# Altium schematic sheet [03] - BUTTONS-AND-SPI.SchDoc("[03] - BUTTONS-AND-SPI")
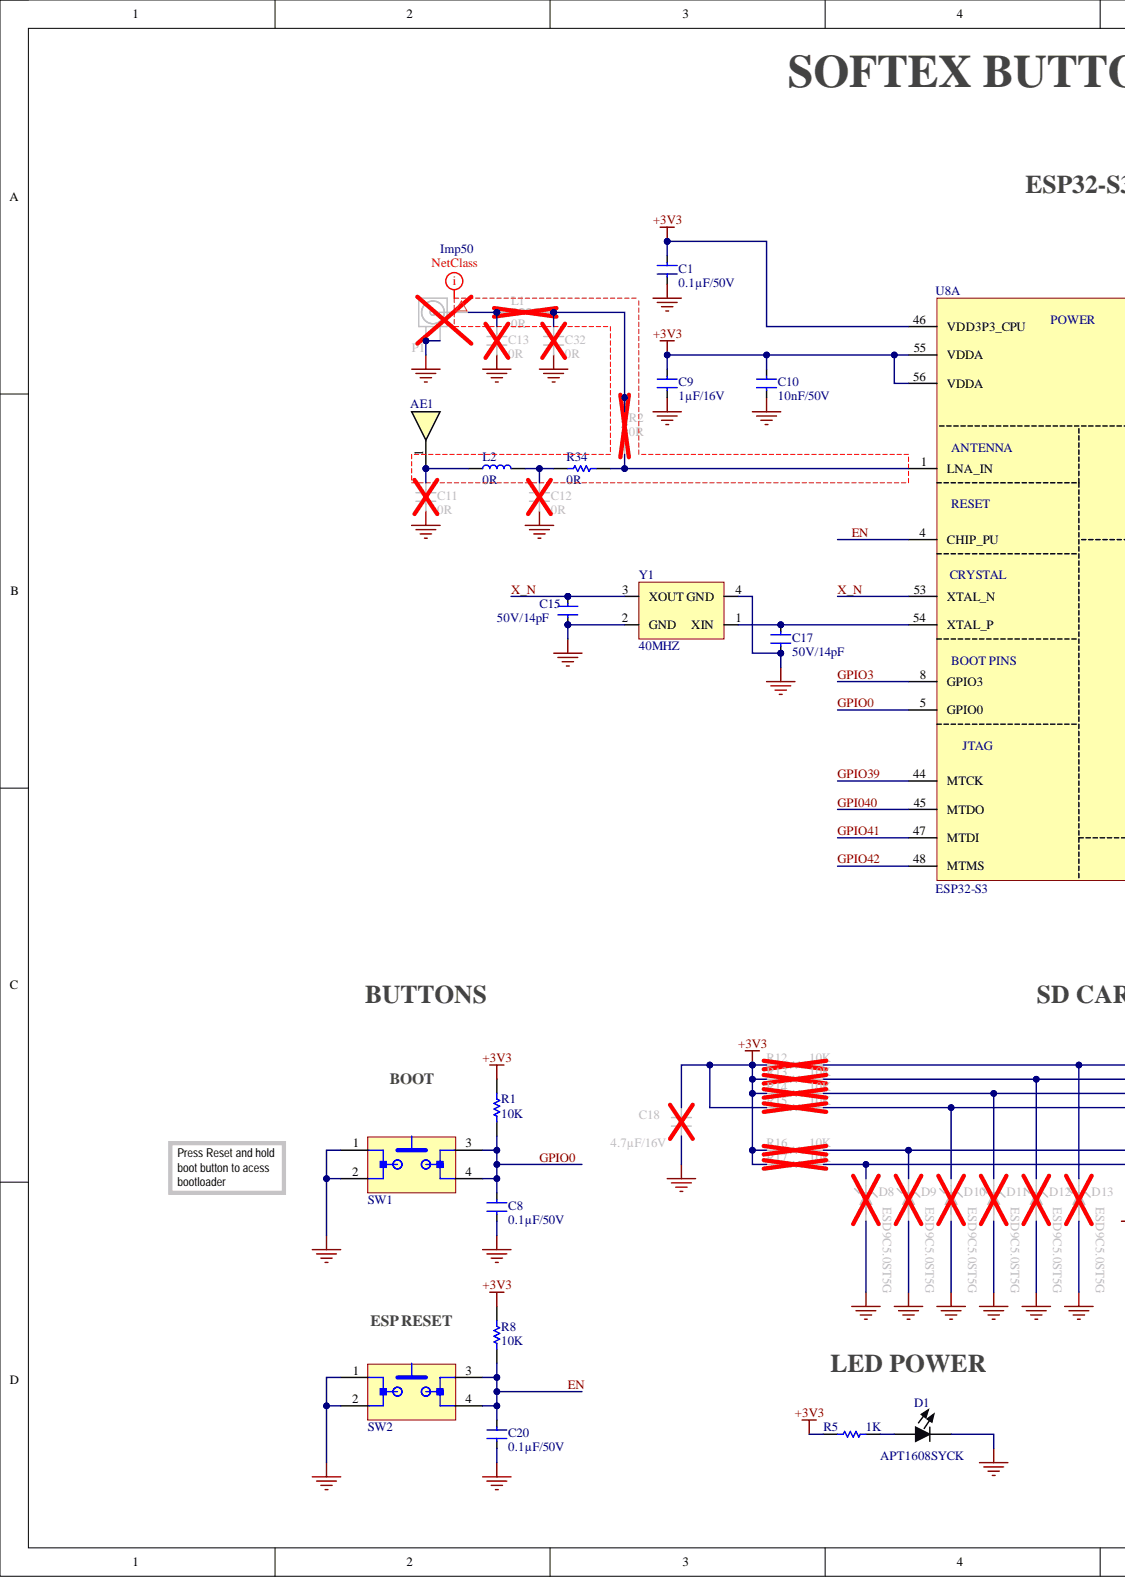
[diagram p0: [03] - BUTTONS-AND-SPI.SchDoc, left]
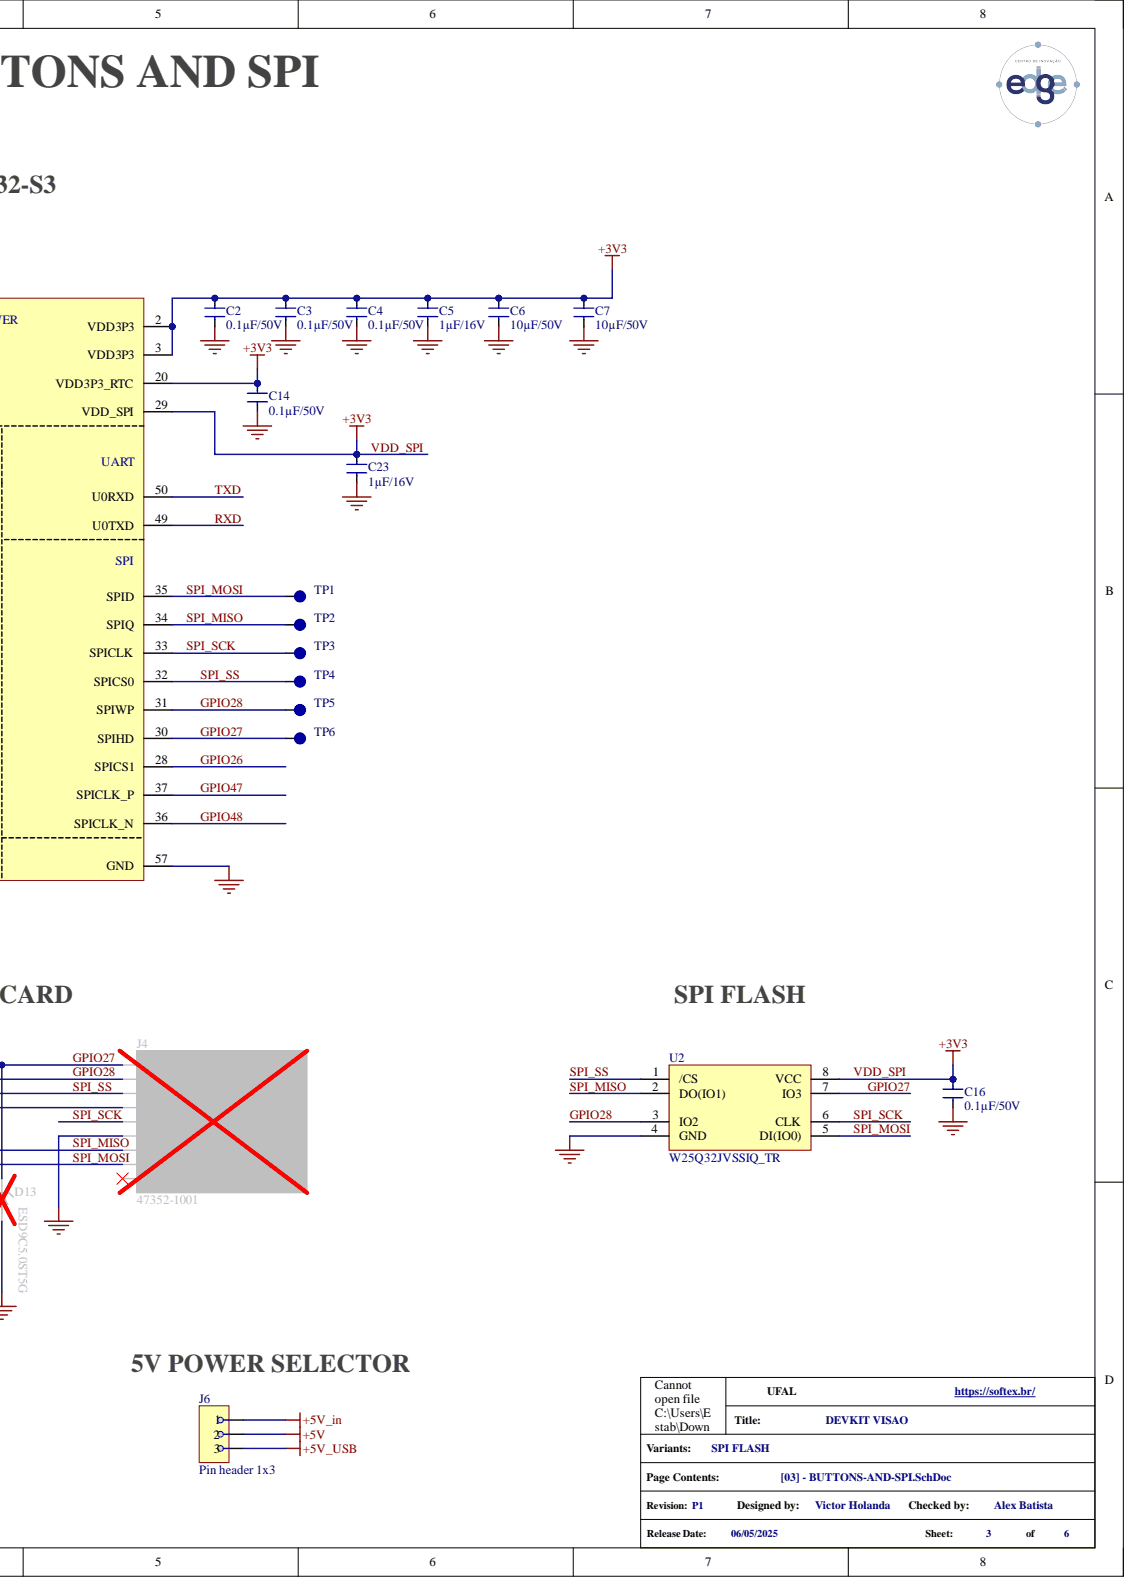
[diagram p1: [03] - BUTTONS-AND-SPI.SchDoc, right]

## Parts
AE1  2450AT45A100E  [2450AT45A100E]  (value not drawn)
C1  0.1µF/50V  [C0805]
C2  0.1µF/50V  [C0805]
C3  0.1µF/50V  [C0805]
C4  0.1µF/50V  [C0805]
C5  1µF/16V  [C0805]
C6  10µF/50V  [C0805]
C7  10µF/50V  [C0805]
C8  0.1µF/50V  [C0805]
C9  1µF/16V  [C0805]
C10  10nF/50V  [C0805]
C11  0R  [C0402]
C12  0R  [C0402]
C13  0R  [C0402]
C14  0.1µF/50V  [C0805]
C15  50V/14pF  [C0402]
C16  0.1µF/50V  [C0805]
C17  50V/14pF  [C0402]
C18  4.7µF/16V  [C0805]
C20  0.1µF/50V  [C0805]
C23  1µF/16V  [C0805]
C32  0R  [C0402]
D1  APT1608SYCK  [APT1608SYCK]
D8  ESD9C5.0ST5G  [ESD9C5.0ST5G]
D9  ESD9C5.0ST5G  [ESD9C5.0ST5G]
D10  ESD9C5.0ST5G  [ESD9C5.0ST5G]
D11  ESD9C5.0ST5G  [ESD9C5.0ST5G]
D12  ESD9C5.0ST5G  [ESD9C5.0ST5G]
D13  ESD9C5.0ST5G  [ESD9C5.0ST5G]
J4  47352-1001  [SDCARD-0473521001]
J6  Pin header 1x3  [PH1-03-UA]
L1  0R  [L0402]
L2  0R  [L0402]
P1  U.FL-R-SMT-1(10)  [U.FL-R-SMT-1(10)]  (value not drawn)
R1  10K  [R0805]
R2  0R  [R0402]
R5  1K  [R0805]
R8  10K  [R0805]
R12  10K  [R0805]
R13  10K  [R0805]
R14  10K  [R0805]
R15  10K  [R0805]
R16  10K  [R0805]
R17  10K  [R0805]
R34  0R  [R0402]
SW1  BUTTON  [BUTTON]  (value not drawn)
SW2  BUTTON  [BUTTON]  (value not drawn)
TP1  TestPoint  [Test Point]  (value not drawn)  (no BOM)
TP2  TestPoint  [Test Point]  (value not drawn)  (no BOM)
TP3  TestPoint  [Test Point]  (value not drawn)  (no BOM)
TP4  TestPoint  [Test Point]  (value not drawn)  (no BOM)
TP5  TestPoint  [Test Point]  (value not drawn)  (no BOM)
TP6  TestPoint  [Test Point]  (value not drawn)  (no BOM)
U2  W25Q32JVSSIQ_TR  [SOIC127P790X216-8N]
U8  ESP32-S3  [ESP32-S3]  (part A)
U8
Y1  40MHZ  [RH100-40.000-10-F-1010-TR]

## Nets
+5V: J6.2
+5V_in: J6.1
+5V_USB: J6.3
GND: C1.1 C2.1 C3.1 C4.1 C5.1 C6.1 C7.1 C8.1 C9.1 C10.1 C11.1 C12.1 C13.1 C14.2 C15.1 C16.1 C17.1 C18.1 C20.1 C23.1 C32.1 D1.2 D8.2 D9.2 D10.2 D11.2 D12.2 D13.2 J4.6(C6/Vss) P1.2 P1.3 SW1.1 SW1.2 SW2.1 SW2.2 U2.4(GND) U8.57 Y1.2(GND) Y1.4(GND)
+3V3: C1.2 C2.2 C3.2 C4.2 C5.2 C6.2 C7.2 C9.2 C10.2 C14.1 C16.2 C18.2 C23.2 R1.2 R5.1 R8.2 R12.1 R13.1 R14.1 R15.1 R16.1 R17.1 U2.8(VCC) U8.2 U8.3 U8.20 U8.29 U8.46 U8.55 U8.56
EN: C20.2 R8.1 SW2.3 SW2.4 U8.4
GPI040: U8.45
GPIO0: C8.2 R1.1 SW1.3 SW1.4 U8.5
GPIO3: U8.8
GPIO26: U8.28 U8.29
GPIO27: D13.1 J4.1(C1/DATA2) R12.2 TP6.1 U2.7(IO3) U8.28 U8.30
GPIO28: D12.1 J4.2(C2/CD/DATA3) R13.2 TP5.1 U2.3(IO2) U8.30 U8.31
GPIO39: U8.44
GPIO41: U8.47
GPIO42: U8.48
GPIO47: U8.37
GPIO48: U8.36
RXD: U8.49
SPI_MISO: D9.1 J4.7(C7/DATA0) R16.2 TP2.1 U2.2(DO(IO1)) U8.33 U8.34
SPI_MOSI: D8.1 J4.8(C8/DATA1) R17.2 TP1.1 U2.5(DI(IO0)) U8.34 U8.35
SPI_SCK: J4.5(C5/CLK) TP3.1 U2.6(CLK) U8.32 U8.33
SPI_SS: D11.1 J4.3(C3/CMD) R14.2 TP4.1 U2.1(/CS) U8.31 U8.32
TXD: U8.49 U8.50
X_N: C15.2 U8.53 Y1.3(XOUT)
NetAE1_1: AE1.1 C11.2 L2.1
NetC12_2: C12.2 L2.2 R34.2
NetC13_2: C13.2 L1.1 P1.1
NetC17_2: C17.2 U8.54 Y1.1(XIN)
NetC32_2: C32.2 L1.2 R2.2
NetD1_1: D1.1 R5.2
NetD10_1: D10.1 J4.4(C4/VDD) R15.2
NetR2_1: R2.1 R34.1 U8.1

Not connected: J4 9(CARD_DET)

## Library data of the parts
AE1 = 2450AT45A100E: RF ANT - 2.4GHZ CHIP SOLDER SMD; Manufacturer 1: Johanson; .PCBChecked: Alex Batista; Bandwidth: 100MHz; Frequency: 2.45GHz; Gain: 1dB; Impedance: 50R; Max Frequency: 2.5GHz; Max Power Dissipation: 3W; Number of Bands: 1; Return Loss: -9.5dB; Component Kind: Standard; ComponentLink1Description: https://datasheet.ciiva.com/1126/1296113-1126176.pdf?src-supplier=Newark; ComponentLink1URL: https://datasheet.ciiva.com/1126/1296113-1126176.pdf?src-supplier=Newark; ComponentLink2Description: https://datasheet.ciiva.com/2791/jti-antenna-2450at45a100-2006-07-2791936.pdf?src-supplier=Digi-Key; ComponentLink2URL: https://datasheet.ciiva.com/2791/jti-antenna-2450at45a100-2006-07-2791936.pdf?src-supplier=Digi-Key; ComponentLink3Description: https://datasheet.ciiva.com/6748/antenna-2450at45a100-v5-6748578.pdf?src-supplier=Newark; ComponentLink3URL: https://datasheet.ciiva.com/6748/antenna-2450at45a100-v5-6748578.pdf?src-supplier=Newark; ComponentLink4Description: https://datasheet.ciiva.com/24068/antenna-2450at45a100-v5-242465-24068002.pdf?src-supplier=Mouser; ComponentLink4URL: https://datasheet.ciiva.com/24068/antenna-2450at45a100-v5-242465-24068002.pdf?src-supplier=Mouser; ComponentLink5Description: https://datasheet.ciiva.com/2791/jti-antenna-2450at45a100-2006-07-2791937.pdf?src-supplier=Digi-Key; ComponentLink5URL: https://datasheet.ciiva.com/2791/jti-antenna-2450at45a100-2006-07-2791937.pdf?src-supplier=Digi-Key; ComponentLink6Description: https://datasheet.ciiva.com/2791/jti-antenna-2450at45a100-2006-07-2791938.pdf?src-supplier=Digi-Key; ComponentLink6URL: https://datasheet.ciiva.com/2791/jti-antenna-2450at45a100-2006-07-2791938.pdf?src-supplier=Digi-Key; ComponentLink7Description: https://datasheet.ciiva.com/1124/1318299-1124920.pdf?src-supplier=Element14; ComponentLink7URL: https://datasheet.ciiva.com/1124/1318299-1124920.pdf?src-supplier=Element14; Height: 0.047inch; Length: 9.5mm; Manufacturer Part Number 1: 2450AT45A100E; Max Operating Temperature: 85°C; Min Operating Temperature: -40°C; Mount: Surface Mount; Number of Pins: 2; Package Quantity: 1; Packaging: Tape and Reel; Power Rating: 3W; Pricing 1: 1=0.94000, 10=0.84100, 25=0.79800, 100=0.65550, 250=0.61276, 500=0.54150 (USD); Radiation Hardening: No; REACH SVHC: No SVHC; Resistor Type: Ceramic; RoHS: Yes; Stock 1: 275; Supplier 1: Digi-Key; Supplier Part Number 1: 712-1012-1-ND; Termination: Solder; Width: 2mm; .SCHChecked: Alex Batista; Library Name: Softex.SchLib
C1-C4 C8 C14 C16 C20 = CL21B104KBCNNNC: MLCC - 0.1µF 0805 50V X7R ±10%; Manufacturer 1: Samsung; .PCBChecked: Victor Holanda; Capacitance: 100nF; Material: Ceramic; Case Code (Imperial): 0805; Case Code (Metric): 2012; Case/Package: 0805; Category: Ceramic Capacitors; Component Kind: Standard; ComponentLink3Description: https://datasheet.ciiva.com/25710/cl21b104kbcnnnc-25710182.pdf?src-supplier=Digi-Key; ComponentLink3URL: https://datasheet.ciiva.com/25710/cl21b104kbcnnnc-25710182.pdf?src-supplier=Digi-Key; Construction: Flat; Contact Plating: Tin; Depth: 1.25mm; Dielectric: X7R; Height: 0.85mm; Lead Free: Lead Free; Length: 2mm; Manufacturer Part Number 1: CL21B104KBCNNNC; Max Operating Temperature: 125°C; Min Operating Temperature: -55°C; Mount: Surface Mount; Packaging: Tape and Reel; Pricing 1: 1=0,10000, 10=0,04800, 50=0,02620, 100=0,02140, 500=0,01528, 1000=0,01201 (USD); Radiation Hardening: No; RoHS: Yes; RoHS Compliant: Yes; Series: CL; Stock 1: 3198898; Supplier 1: Digi-Key; Supplier 2: Mouser; Supplier Part Number 1: 1276-1003-1-ND; Supplier Part Number 2: 187-CL21B104KBCNNNC; Thickness: 0.037inch; Tolerance: 10%; Voltage: 50V; Voltage Rating: 50V; Voltage Rating (DC): 50V; Width: 0.049inch; .SCHChecked: Victor Holanda; Library Name: Softex.SchLib
C5 C9 C23 = CL21B105KOFNNNE: MLCC - 1µF 0805 16V X7R ±10%; Manufacturer 1: Samsung; .PCBChecked: Victor Holanda; Capacitance: 1uF; Case Code (Imperial): 0805; Case Code (Metric): 2012; Case/Package: 0805; Category: Ceramic Capacitors; Component Kind: Standard; ComponentLink3Description: https://datasheet.ciiva.com/25800/cl21b105kofnnne-25800257.pdf?src-supplier=Digi-Key; ComponentLink3URL: https://datasheet.ciiva.com/25800/cl21b105kofnnne-25800257.pdf?src-supplier=Digi-Key; Construction: Flat; Contact Plating: Tin; Depth: 1.25mm; Dielectric: X7R; Height: 1.25mm; Lead Free: Lead Free; Length: 2mm; Manufacturer Part Number 1: CL21B105KOFNNNE; Max Operating Temperature: 125°C; Min Operating Temperature: -55°C; Mount: Surface Mount; Package Quantity: 1; Packaging: Tape and Reel; Pricing 1: 1=0,10000, 10=0,05600, 50=0,03060, 100=0,02500, 500=0,01782, 1000=0,01401 (USD); Radiation Hardening: No; RoHS: Yes; RoHS Compliant: Yes; Series: CL; Stock 1: 1044699; Supplier 1: Digi-Key; Supplier Part Number 1: 1276-1026-1-ND; Thickness: 0.053inch; Tolerance: 10%; Voltage: 16V; Voltage Rating: 16V; Voltage Rating (DC): 16V; Width: 0.049inch; .SCHChecked: Victor Holanda; Library Name: Softex.SchLib
C6 C7 = GRM21BR61H106ME43L: MLCC - 10µF 0805 50V X7R ±20%; Manufacturer  1: Murata; .PCBChecked: Victor Holanda; Category: Ceramic Capacitors; Component Kind: Standard; Manufacturer Part Number 1: GRM21BR61H106ME43L; Pricing 1: 1=0,42000, 10=0,29400, 50=0,20560, 100=0,17620, 500=0,13216, 1000=0,11161 (USD); RoHS: Yes; Stock 1: 199741; Supplier 1: Digi-Key; Supplier Part Number 1: 490-18664-1-ND; .SCHChecked: Victor Holanda; Library Name: Softex.SchLib
C10 = CL21B103KBCNNNC: MLCC - 10nF 0805 50V X7R ±10%; Manufacturer 1: Samsung; .PCBChecked: Victor Holanda; Capacitance: 10nF; Case Code (Imperial): 0805; Case Code (Metric): 2012; Case/Package: 0805; Category: Ceramic Capacitors; Component Kind: Standard; ComponentLink6Description: https://datasheet.ciiva.com/26920/getdatasheetpartid-640087-26920198.pdf?src-supplier=Verical; ComponentLink6URL: https://datasheet.ciiva.com/26920/getdatasheetpartid-640087-26920198.pdf?src-supplier=Verical; Construction: Flat; Contact Plating: Tin; Depth: 1.25mm; Dielectric: X7R; Height: 0.85mm; Lead Free: Lead Free; Length: 2mm; Manufacturer Part Number 1: CL21B103KBCNNNC; Max Operating Temperature: 125°C; Min Operating Temperature: -55°C; Mount: Surface Mount; Packaging: Tape and Reel; Pricing 1: 1=0,10000, 10=0,05000, 50=0,02700, 100=0,02210, 500=0,01578, 1000=0,01240 (USD); Radiation Hardening: No; RoHS: Yes; RoHS Compliant: Yes; Series: CL; Stock 1: 106601; Supplier 1: Digi-Key; Supplier Part Number 1: 1276-1078-1-ND; Thickness: 0.037inch; Tolerance: 10%; Voltage Rating: 50V; Voltage Rating (DC): 50V; Width: 0.049inch; .SCHChecked: Victor Holanda; Library Name: Softex.SchLib
C11-C13 C32 = C_0402_JUMPER: MLCC - 0R 0402 JUMPER; Manufacturer 1: Vishay; Manufacturer Part Number 1: CRCW04020000Z0EDC; .PCBChecked: Victor Holanda; Power Rating: 62.5mW; Resistance: 18R; Case/Package: Molded; Category: Chip SMD Resistors; Component Kind: Standard; ComponentLink1Description: http://www.vishay.com/docs/28773/crcwce3.pdf?src-supplier=Digi-Key; ComponentLink1URL: http://www.vishay.com/docs/28773/crcwce3.pdf?src-supplier=Digi-Key; ComponentLink2Description: http://www.vishay.com/docs/20035/dcrcwe3.pdf?src-supplier=Avnet; ComponentLink2URL: http://www.vishay.com/docs/20035/dcrcwe3.pdf?src-supplier=Avnet; Contact Plating: Tin; Lead Free: Lead Free; Length: 1mm; Max Operating Temperature: 155°C; Min Operating Temperature: -55°C; Mount: Surface Mount; Pricing 1: 1=0,10000, 10=0,02300, 100=0,00950, 1000=0,00427, 2500=0,00370, 5000=0,00306 (USD); Resistor Type: Thick Film; RoHS: Yes; RoHS Compliant: Yes; Stock 1: 109511; Supplier 1: Digi-Key; Supplier Part Number 1: 541-4062-1-ND; Termination: Wraparound; .SCHChecked: Victor Holanda; Library Name: Softex.SchLib
C15 C17 = 04025U140FAT2A: MLCC - 14pF 0402 50V NP0 ±1%; Manufacturer 1: Kyocera AVX; .PCBChecked: Victor H; Dielectric: C0G; Capacitance: 14pF; Case Code (Imperial): 0402; Case Code (Metric): 1005; Case/Package: 0402; Category: Ceramic Capacitors; Component Kind: Standard; ComponentLink1Description: https://datasheet.ciiva.com/11568/useries-11568551.pdf?src-supplier=Avnet; ComponentLink1URL: https://datasheet.ciiva.com/11568/useries-11568551.pdf?src-supplier=Avnet; ComponentLink2Description: https://datasheet.ciiva.com/6269/useries-6269558.pdf?src-supplier=Digi-Key; ComponentLink2URL: https://datasheet.ciiva.com/6269/useries-6269558.pdf?src-supplier=Digi-Key; Construction: Flat; Depth: 0.5mm; Length: 1mm; Manufacturer Part Number 1: 04025U140FAT2A; Max Operating Temperature: 125°C; Min Operating Temperature: -55°C; Mount: Surface Mount; Package Quantity: 10000; Packaging: Tape and Reel; Pricing 1: 1=0,37000, 10=0,25100, 50=0,16760, 100=0,14250, 500=0,10058, 1000=0,08801, 2500=0,08382, 5000=0,07753 (USD); Radiation Hardening: No; Resistor Type: Ceramic; RoHS: Yes; RoHS Compliant: Yes; Series: U; Stock 1: 104186; Supplier 1: Digi-Key; Supplier Part Number 1: 478-10139-1-ND; Termination: SMD/SMT; Thickness: 0.022inch; Tolerance: 1%; Voltage Rating: 50V; Voltage Rating (DC): 50V; Width: 0.5mm; .SCHChecked: Victor H; Library Name: softex-devkit-visao.SchLib
C18 = CL21A475KOFNNNE: MLCC - 4.7µF 0805 16V X5R ±10%; Manufacturer 1: Samsung; .PCBChecked: Victor Holanda; Capacitance: 4.7uF; Case Code (Imperial): 0805; Case Code (Metric): 2012; Case/Package: 0805; Category: Ceramic Capacitors; Component Kind: Standard; ComponentLink3Description: https://datasheet.ciiva.com/25710/cl21a475kofnnne-25710354.pdf?src-supplier=Digi-Key; ComponentLink3URL: https://datasheet.ciiva.com/25710/cl21a475kofnnne-25710354.pdf?src-supplier=Digi-Key; ComponentLink4Description: https://datasheet.ciiva.com/16740/cl21a475kofnnne-16740646.pdf?src-supplier=Future+Electronics; ComponentLink4URL: https://datasheet.ciiva.com/16740/cl21a475kofnnne-16740646.pdf?src-supplier=Future+Electronics; ComponentLink5Description: https://datasheet.ciiva.com/26838/getdatasheetpartid-357424-26838625.pdf?src-supplier=Verical; ComponentLink5URL: https://datasheet.ciiva.com/26838/getdatasheetpartid-357424-26838625.pdf?src-supplier=Verical; Construction: Flat; Contact Plating: Tin; Depth: 1.25mm; Dielectric: X5R; Height: 1.25mm; Length: 2mm; Manufacturer Part Number 1: CL21A475KOFNNNE; Max Operating Temperature: 85°C; Min Operating Temperature: -55°C; Mount: Surface Mount; Packaging: Tape and Reel; Pricing 1: 1=0,12000, 10=0,08600, 50=0,04880, 100=0,04050, 500=0,02838, 1000=0,02350 (USD); Radiation Hardening: No; RoHS: Yes; RoHS Compliant: Yes; Series: CL; Stock 1: 605133; Supplier 1: Digi-Key; Supplier Part Number 1: 1276-1065-1-ND; Thickness: 0.053inch; Tolerance: 10%; Voltage Rating: 16V; Width: 0.049inch; .SCHChecked: Victor Holanda; Library Name: softex-devkit-visao.SchLib
D1 = APT1608SYCK: LED - APT1608SYCK Yellow LED; 590 nm 1608 (0603) Clear; Rectangle Lens SMD package; Manufacturer: Kingbright; .PCBChecked: Victor H; Dominant Wavelength: 590nm; Forward Current: 20mA; Forward Voltage: 2V; Lens Style: Clear,Flat; Luminous Intensity: 150mcd; Number of LEDs: 1; Viewing Angle: 120°; Case Code (Imperial): 0603; Case Code (Metric): 1608; Case/Package: 0603; Category: LEDs; Color: Yellow; Component Kind: Standard; ComponentLink1Description: https://datasheet.ciiva.com/2113/apt1608syck-2113824.pdf?src-supplier=Digi-Key; ComponentLink1URL: https://datasheet.ciiva.com/2113/apt1608syck-2113824.pdf?src-supplier=Digi-Key; ComponentLink2Description: https://datasheet.ciiva.com/4688/apt1608syck-35136-4688900.pdf?src-supplier=Mouser; ComponentLink2URL: https://datasheet.ciiva.com/4688/apt1608syck-35136-4688900.pdf?src-supplier=Mouser; ComponentLink3Description: https://datasheet.ciiva.com/2113/apt1608syck-2113825.pdf?src-supplier=Digi-Key; ComponentLink3URL: https://datasheet.ciiva.com/2113/apt1608syck-2113825.pdf?src-supplier=Digi-Key; ComponentLink4Description: https://datasheet.ciiva.com/2113/apt1608syck-2113826.pdf?src-supplier=Digi-Key; ComponentLink4URL: https://datasheet.ciiva.com/2113/apt1608syck-2113826.pdf?src-supplier=Digi-Key; ComponentLink5Description: https://datasheet.ciiva.com/14603/70062842-14603786.pdf?src-supplier=Allied+Electronics; ComponentLink5URL: https://datasheet.ciiva.com/14603/70062842-14603786.pdf?src-supplier=Allied+Electronics; Height: 0.75mm; Illumination Color: Yellow; Lead Free: Lead Free; Length: 1.6mm; Lens Color: Clear; Manufacturer Part Number: APT1608SYCK; Max Operating Temperature: 85°C; Min Operating Temperature: -40°C; Mount: Surface Mount; mounting: SMD; Number of Pins: 2; Package Quantity: 2000; Packaging: Tape and Reel; Pricing 1: 1=0,31000, 10=0,24100, 100=0,10840, 500=0,09794, 1000=0,08045 (USD); RoHS: Yes; RoHS Compliant: Yes; Series: APT; Stock 1: 448438; Supplier 1: Digi-Key; Supplier Part Number 1: 754-1124-1-ND; Test Current: 20mA; Wavelength: 590nm; Width: 0.8mm; .SCHChecked: Victor H; Library Name: softex-devkit-visao.SchLib
D8-D13 = ESD9C5.0ST5G: DIODE - TVS 5V SOD923; Manufacturer: ON Semiconductor; .PCBChecked: Alex Batista; Breakdown Voltage: 11V; Capacitance: 6.2pF; Clamping Voltage: 10V; Diode Type: ZENER; Direction: Unidirectional; Element Configuration: Single; ESD Protection: Yes; Leakage Current: 500nA; Max Power Dissipation: 150mW; Number of Channels: 1; Power Line Protection: No; Test Current: 1mA; Case/Package: SOD-923-2; Category: ESD and Circuit Protection ICs; Clamping Voltage-Max: 10V; Component Kind: Standard; ComponentLink1Description: https://datasheet.ciiva.com/1219/1748974-1219321.pdf?src-supplier=Newark; ComponentLink1URL: https://datasheet.ciiva.com/1219/1748974-1219321.pdf?src-supplier=Newark; ComponentLink2Description: https://datasheet.ciiva.com/4509/esd9c3-3s-d-241629-4509822.pdf?src-supplier=Mouser; ComponentLink2URL: https://datasheet.ciiva.com/4509/esd9c3-3s-d-241629-4509822.pdf?src-supplier=Mouser; ComponentLink3Description: https://datasheet.ciiva.com/15143/getdatasheetpartid-53763-15143125.pdf?src-supplier=Verical; ComponentLink3URL: https://datasheet.ciiva.com/15143/getdatasheetpartid-53763-15143125.pdf?src-supplier=Verical; ComponentLink4Description: https://datasheet.ciiva.com/339/esd9c3-3s-d-339778.pdf?src-supplier=Digi-Key; ComponentLink4URL: https://datasheet.ciiva.com/339/esd9c3-3s-d-339778.pdf?src-supplier=Digi-Key; ComponentLink5Description: https://datasheet.ciiva.com/11195/esd9c3-3s-d-11195341.pdf?src-supplier=Avnet; ComponentLink5URL: https://datasheet.ciiva.com/11195/esd9c3-3s-d-11195341.pdf?src-supplier=Avnet; ComponentLink6Description: https://datasheet.ciiva.com/339/esd9c3-3s-d-339779.pdf?src-supplier=Digi-Key; ComponentLink6URL: https://datasheet.ciiva.com/339/esd9c3-3s-d-339779.pdf?src-supplier=Digi-Key; ComponentLink7Description: https://datasheet.ciiva.com/339/esd9c3-3s-d-339780.pdf?src-supplier=Digi-Key; ComponentLink7URL: https://datasheet.ciiva.com/339/esd9c3-3s-d-339780.pdf?src-supplier=Digi-Key; Depth: 0.6mm; Halogen Free: Halogen Free; Height: 0.4mm; Lead Free: Lead Free; Length: 0.8mm; Manufacturer Part Number: ESD9C5.0ST5G; Max Breakdown Voltage: 11V; Max Operating Temperature: 150°C; Max Reverse Leakage Current: 500nA; Min Breakdown Voltage: 11V; Min Operating Temperature: -55°C; Number of Elements: 1; Number of Lines: 1; Number of Pins: 2; Number of Unidirectional Channels: 1; Operating Supply Voltage: 5V; Package Quantity: 1; Packaging: Tape and Reel; Peak Pulse Power: 150mW; Polarity: Unidirectional; Pricing 1: 1=0,32000, 10=0,25900, 100=0,13720, 500=0,09024, 1000=0,06136, 2000=0,05535 (USD); Radiation Hardening: No; REACH SVHC: No SVHC; Resistor Type: Zener; Reverse Breakdown Voltage: 11V; Reverse Standoff Voltage: 5V; RoHS: Yes; RoHS Compliant: Yes; Series: Automotive, AEC-Q101; Stock 1: 4445; Supplier 1: Digi-Key; Supplier Part Number 1: ESD9C5.0ST5GOSCT-ND; Termination: SMD/SMT; Width: 0.65mm; Working Voltage: 5V; .SCHChecked: Alex Batista; Library Name: softex-devkit-visao.SchLib
J4 = 47352-1001: CONN - Micro SD Card HDR 8 POS 1.1mm Solder RA SMD 0.5A/Contact Embossed T/R; Manufacturer 1: Molex; .PCBChecked: Alex Batista; Connector Type: Connector; Current Rating: 500mA; Gender: Male; Max Voltage Rating (DC): 100V; Number of Contacts: 8; Category: Memory Connectors; Component Kind: Standard; ComponentLink1Description: https://datasheet.ciiva.com/683/473521001-sd-683625.pdf?src-supplier=Digi-Key; ComponentLink1URL: https://datasheet.ciiva.com/683/473521001-sd-683625.pdf?src-supplier=Digi-Key; ComponentLink2Description: https://datasheet.ciiva.com/11755/0900766b80f75287-11755041.pdf?src-supplier=RSComponents; ComponentLink2URL: https://datasheet.ciiva.com/11755/0900766b80f75287-11755041.pdf?src-supplier=RSComponents; ComponentLink3Description: https://datasheet.ciiva.com/16547/0473521001-memory-card-socket-16547169.pdf?src-supplier=Avnet+Europe; ComponentLink3URL: https://datasheet.ciiva.com/16547/0473521001-memory-card-socket-16547169.pdf?src-supplier=Avnet+Europe; ComponentLink4Description: https://datasheet.ciiva.com/30105/0473521001-memory-card-socket-30105258.pdf?src-supplier=Onlinecomponents.com; ComponentLink4URL: https://datasheet.ciiva.com/30105/0473521001-memory-card-socket-30105258.pdf?src-supplier=Onlinecomponents.com; ComponentLink5Description: https://datasheet.ciiva.com/683/473521001-sd-683627.pdf?src-supplier=Digi-Key; ComponentLink5URL: https://datasheet.ciiva.com/683/473521001-sd-683627.pdf?src-supplier=Digi-Key; Contact Material: Stainless Steel,Copper Alloy,Copper; Contact Plating: Gold; Depth: 15.2mm; Housing Material: Polymer; Length: 14mm; Manufacturer Part Number 1: 47352-1001; Max Operating Temperature: 85°C; Min Operating Temperature: -20°C; Mount: Surface Mount; Number of Rows: 1; Orientation: Right Angle; Package Quantity: 1; Packaging: Tape and Reel; Pitch: 1.1mm; Pricing 1: 1=3,58000, 10=3,15500, 100=2,50930 (USD); Pricing 2: 1=3,58000, 10=3,16000, 50=3,16000, 100=2,66000, 1000=2,16000, 10000=2,15000 (USD); Radiation Hardening: No; REACH SVHC: Unknown; RoHS: Yes; Series: 47352; Stock 1: 14775; Supplier 1: Digi-Key; Supplier 2: Mouser; Supplier Part Number 1: WM3290CT-ND; Supplier Part Number 2: 538-47352-1001; Termination: SMD/SMT; Voltage Rating (DC): 100V; Width: 1.88mm; .SCHChecked: Alex Batista; Library Name: softex-devkit-visao.SchLib
J6 = PH1-03-UA: CONN - HEADER VERT 3POS 2.54MM; Manufacturer 1: Adam Equipment; .PCBChecked: Victor H; Number of Positions: 3; Category: Connectors; Component Kind: Standard; ComponentLink1Description: https://datasheet.ciiva.com/16396/catpg240-254-16396026.pdf?src-supplier=Future+Electronics; ComponentLink1URL: https://datasheet.ciiva.com/16396/catpg240-254-16396026.pdf?src-supplier=Future+Electronics; Lead Free: Lead Free; Manufacturer Part Number 1: PH1-03-UA; Pitch: 2.54mm; Pricing 1: 1=0,10000, 10=0,05000, 100=0,03650, 500=0,03024, 1000=0,02646, 5000=0,02268, 10000=0,02205 (USD); RoHS: Yes; Stock 1: 48736; Supplier 1: Digi-Key; Supplier Part Number 1: 2057-PH1-03-UA-ND; .SCHChecked: Victor H; Library Name: softex-devkit-visao.SchLib
L1 L2 = L_0402_JUMPER: IND - 0R 0402 JUMPER; Manufacturer 1: Vishay; Manufacturer Part Number 1: CRCW04020000Z0EDC; .PCBChecked: Alex Batista; Power Rating: 62.5mW; Resistance: 18R; Case/Package: Molded; Component Kind: Standard; Composition: Thick Film; Contact Plating: Tin; Lead Free: Lead Free; Length: 1mm; Max Operating Temperature: 155°C; Min Operating Temperature: -55°C; Mount: Surface Mount; Pricing 1: 1=0.13, 10=0.044, 50=0.044, 100=0.025, 1000=0.018, 10000=0.005 (USD); Stock 1: 1494844; Supplier 1: Mouser; Supplier Part Number 1: 71-CRCW04020000Z0EDC; Termination: Wraparound; .SCHChecked: Alex Batista; Library Name: Softex.SchLib
P1 = U.FL-R-SMT-1(10): Connector - UFL; Manufacturer 1: Hirose; .PCBChecked: Alex Batista; Body Material: Bronze; Body Plating: Silver; Connector Type: Coaxial,Receptacle,Male Pin; Dielectric Material: Polymer; Frequency: 6GHz; Gender: Male; Impedance: 50R; Insulation Resistance: 500MR; Wire/Cable Type: Coaxial; Component Kind: Standard; ComponentLink1Description: https://datasheet.ciiva.com/11762/0900766b8105243f-11762474.pdf?src-supplier=RSComponents; ComponentLink1URL: https://datasheet.ciiva.com/11762/0900766b8105243f-11762474.pdf?src-supplier=RSComponents; ComponentLink2Description: https://datasheet.ciiva.com/7651/91524-7651914.pdf?src-supplier=Element14; ComponentLink2URL: https://datasheet.ciiva.com/7651/91524-7651914.pdf?src-supplier=Element14; ComponentLink3Description: https://datasheet.ciiva.com/7651/91524-7651915.pdf?src-supplier=Element14; ComponentLink3URL: https://datasheet.ciiva.com/7651/91524-7651915.pdf?src-supplier=Element14; Contact Material: Brass,Bronze; Contact Plating: Gold; Depth: 3.1mm; Fastening Type: Snap; Housing Color: Beige; Lead Free: Lead Free; Length: 3mm; Manufacturer 2: Hirose; Manufacturer Part Number 1: U.FL-R-SMT-1(10); Manufacturer Part Number 2: U.FL-R-SMT-1(10); Max Frequency: 6GHz; Max Operating Temperature: 90°C; Min Operating Temperature: -40°C; Mount: Surface Mount,Through Hole,PCB; Orientation: Straight; Package Quantity: 2500; Packaging: Tape and Reel; Pricing 1: 1=1.310000, 10=1.150000, 25=1.082400, 50=1.037400, 100=0.992200, 250=0.902000, 500=0.811800, 1000=0.721600 (USD); Pricing 2: 1=1.190000, 10=0.984000, 50=0.984000, 100=0.903000, 250=0.820000, 500=0.753000, 1000=0.656000, 2500=0.595000, 10000=0.595000 (USD); Radiation Hardening: No; REACH SVHC: No SVHC; RoHS: Yes; Stock 1: 249511; Stock 2: 42299; Supplier 1: Digi-Key; Supplier 2: Mouser; Supplier Part Number 1: H11891CT-ND; Supplier Part Number 2: 798-U.FL-R-SMT-110; Termination: Solder; .SCHChecked: Alex Batista; Library Name: Softex.SchLib
R1 R8 R12-R17 = RNCP0805FTD10K0: RES - 10K 1/4W 0805 ±1%; Manufacturer 1: Stackpole Electronics; .PCBChecked: Victor Holanda; Max Power Dissipation: 250mW; Resistance: 10kR; Temperature Coefficient: 100ppm/°C; Case Code (Imperial): 0805; Case Code (Metric): 2012; Case/Package: 0805; Category: Chip SMD Resistors; Component Kind: Standard; ComponentLink7Description: https://www.seielect.com/catalog/SEI-Packaging.pdf?src-supplier=Digi-Key; ComponentLink7URL: https://www.seielect.com/catalog/SEI-Packaging.pdf?src-supplier=Digi-Key; Features: Moisture Resistant,Anti-Sulfur; Height: 0.024inch; Lead Free: Lead Free; Length: 0.079inch; Manufacturer Part Number 1: RNCP0805FTD10K0; Max Operating Temperature: 155°C; Min Operating Temperature: -55°C; Mount: Surface Mount; Number of Terminations: 2; Packaging: Tape and Reel; Pricing 1: 1=0,10000, 10=0,07300, 100=0,02970, 1000=0,01336, 2500=0,01159 (USD); Resistor Type: Thin Film; RoHS: Yes; RoHS Compliant: Yes; Series: RNCP; Stock 1: 744134; Supplier 1: Digi-Key; Supplier Part Number 1: RNCP0805FTD10K0CT-ND; Tolerance: 1%; Width: 0.049inch; .SCHChecked: Victor Holanda; Library Name: Softex.SchLib
R2 R34 = RMCF0402ZT0R00: RES - 0R 1/16W 0402; Manufacturer: Stackpole Electronics; .PCBChecked: Victor Holanda; Current Rating: 1A; Max Power Dissipation: 63mW; Working Voltage: 50V; Case Code (Imperial): 0402; Case Code (Metric): 1005; Case/Package: 0402; Category: Chip SMD Resistors; Component Kind: Standard; ComponentLink1Description: https://datasheet.ciiva.com/26835/getdatasheetpartid-409751-26835612.pdf?src-supplier=Verical; ComponentLink1URL: https://datasheet.ciiva.com/26835/getdatasheetpartid-409751-26835612.pdf?src-supplier=Verical; Construction: Rectangular; Contact Plating: Tin,Matte; Depth: 0.5mm; Features: Automotive AEC-Q200; Height: 0.016inch; Lead Free: Lead Free; Length: 0.039inch; Manufacturer Part Number: RMCF0402ZT0R00; Max Operating Temperature: 155°C; Military Standard: Not; Min Operating Temperature: -55°C; Mount: Surface Mount; Number of Terminations: 2; Packaging: Tape and Reel; Power Rating: 63mW; Pricing 1: 1=0,10000, 10=0,01000, 25=0,00720, 50=0,00560, 100=0,00410, 250=0,00316, 500=0,00252, 1000=0,00186, 5000=0,00133 (USD); Radiation Hardening: No; Resistance: 0R; Resistor Type: Thick Film; RoHS: Yes; RoHS Compliant: Yes; Series: RMCF; Stock 1: 13640274; Supplier 1: Digi-Key; Supplier Part Number 1: RMCF0402ZT0R00CT-ND; Technology: THICK FILM; Width: 0.02inch; .SCHChecked: Victor Holanda; Library Name: softex-devkit-visao.SchLib
R5 = RNCP0805FTD1K00: RES - 1K 1/4W 0805 ±1%; Manufacturer 1: Stackpole Electronics; .PCBChecked: Victor Holanda; Max Power Dissipation: 250mW; Resistance: 1kR; Temperature Coefficient: 100ppm/°C; Case Code (Imperial): 0805; Case Code (Metric): 2012; Case/Package: 0805; Category: Chip SMD Resistors; Component Kind: Standard; ComponentLink7Description: http://www.seielect.com/Catalog/SEI-rncp.pdf?src-supplier=Future+Electronics; ComponentLink7URL: http://www.seielect.com/Catalog/SEI-rncp.pdf?src-supplier=Future+Electronics; ComponentLink8Description: https://www.seielect.com/Catalog/SEI-rncp.pdf?src-supplier=Digi-Key; ComponentLink8URL: https://www.seielect.com/Catalog/SEI-rncp.pdf?src-supplier=Digi-Key; ComponentLink9Description: https://www.seielect.com/catalog/SEI-Packaging.pdf?src-supplier=Digi-Key; ComponentLink9URL: https://www.seielect.com/catalog/SEI-Packaging.pdf?src-supplier=Digi-Key; Features: Moisture Resistant,Anti-Sulfur; Height: 0.024inch; Lead Free: Lead Free; Length: 0.079inch; Manufacturer Part Number 1: RNCP0805FTD1K00; Max Operating Temperature: 155°C; Min Operating Temperature: -55°C; Mount: Surface Mount; Number of Terminations: 2; Packaging: Tape and Reel; Power Rating: 250mW; Pricing 1: 1=0,10000, 10=0,07300, 100=0,02970, 1000=0,01336, 2500=0,01159 (USD); Resistor Type: Thin Film; RoHS: Yes; RoHS Compliant: Yes; Series: RNCP; Size Code: 0805; Stock 1: 333101; Supplier 1: Digi-Key; Supplier Part Number 1: RNCP0805FTD1K00CT-ND; Tolerance: 1%; Width: 0.049inch; .SCHChecked: Victor Holanda; Library Name: Softex.SchLib
SW1 SW2 = PTS645SM43SMTR92 LFS: SWITCH TACTILE SPST-NO 0.05A 12V; .PCBChecked: Victor H; Component Kind: Standard; Supplier 1: Digi-Key; Supplier Part Number 1: CKN9112CT-ND; .SCHChecked: Victor H; Library Name: Softex.SchLib
TP1-TP6 = Test_Point: MECH - Test Points; Library Name: softex-devkit-visao.SchLib
U2 = W25Q32JVSSIQ_TR: IC - NOR Flash Serial (SPI, Dual SPI, Quad SPI) 3V/3.3V 32M-bit 4M x 8 6ns 8-Pin SOIC T/R; Manufacturer 1: Winbond; Manufacturer Part Number 1: W25Q32JVSSIQ TR; Frequency: 104MHz; Interface: SPI,Serial; Memory Type: FLASH,NOR; Case/Package: SOIC; Category: Flash; Component Kind: Standard; ComponentLink1Description: http://www.winbond.com/resource-files/w25q32jv%20revg%2003272018%20plus.pdf?src-supplier=Digi-Key; ComponentLink1URL: http://www.winbond.com/resource-files/w25q32jv%20revg%2003272018%20plus.pdf?src-supplier=Digi-Key; Max Operating Temperature: 85°C; Min Operating Temperature: -40°C; Packaging: Tape and Reel; Pricing 1: 1=0,94000, 10=0,85700, 25=0,84640, 50=0,84120, 100=0,75220, 250=0,74580, 500=0,73480, 1000=0,70850 (USD); RoHS: Yes; RoHS Compliant: Yes; Stock 1: 124113; Supplier 1: Digi-Key; Supplier Part Number 1: W25Q32JVSSIQCT-ND; Library Name: softex-devkit-visao.SchLib
U8 = ESP32-S3: IC - SMD ESP32-S3, Dual-core Mcu,; Manufacturer: Espressif Systems; .PCBChecked: Victor H; Component Kind: Standard; Manufacturer Part Number: ESP32-S3; mounting: SMD; Pricing 1: 1=2,04000 (USD); RoHS: No; Stock 1: 2000; Supplier 1: Digi-Key; Supplier Part Number 1: 1965-ESP32-S3-ND; .SCHChecked: Victor H; Library Name: softex-devkit-visao.SchLib
Y1 = RH100-40.000-10-F-1010-TR: Crystal - 40MHz ±10ppm (Tol) ±10ppm (Stability) 10pF FUND 60Ohm 4-Pin SMD T/R; Manufacturer 1: Raltron Electronics; .PCBChecked: Victor H; Component Kind: Standard; Manufacturer Part Number 1: RH100-40.000-10-F-1010-TR; Pricing 1: 1=0,39000, 10=0,32400, 50=0,29180, 100=0,25940, 500=0,24640, 1000=0,20750 (USD); RoHS: Yes; Stock 1: 8779; Supplier 1: Digi-Key; Supplier Part Number 1: 2151-RH100-40.000-10-F-1010-TRCT-ND; .SCHChecked: Victor H; Library Name: softex-devkit-visao.SchLib
